# Altium schematic sheet Power.SchDoc
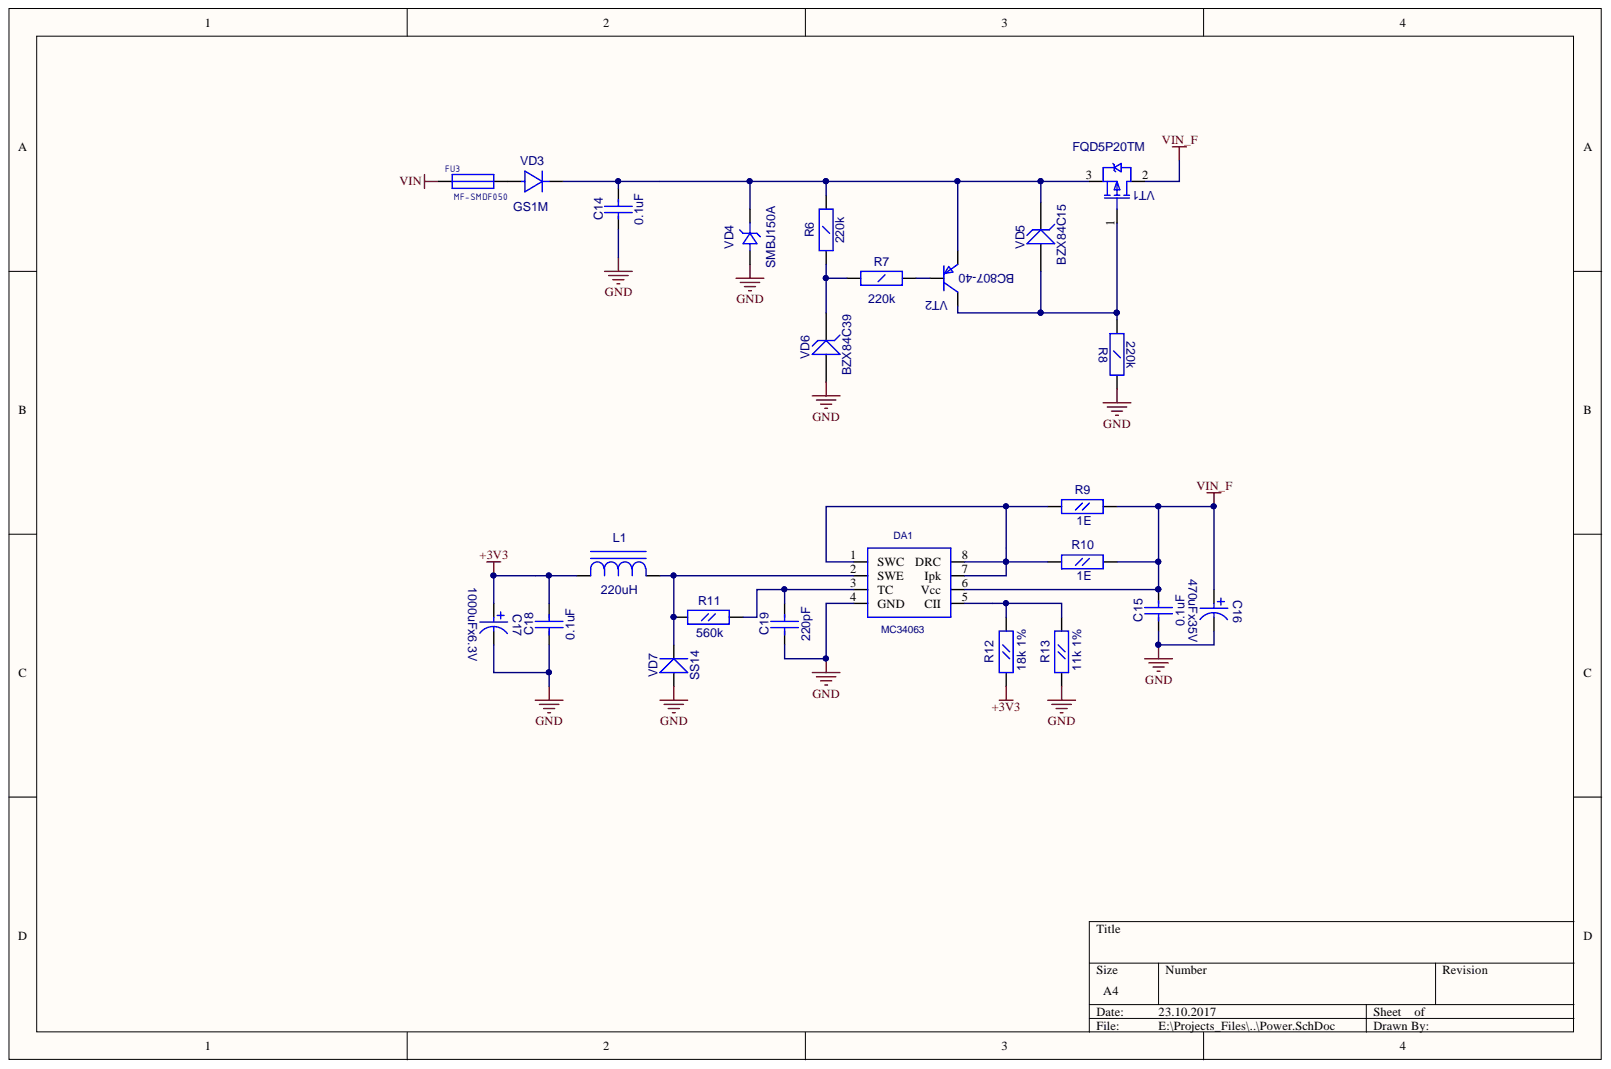
[diagram p0: Power.SchDoc]

## Parts
C14  0.1uF  [CC1206]
C15  0.1uF  [CC0603]
C16  470uFx35V  [CC16X10_CAP0.5(1)(Primary)]
C17  1000uFx6.3V  [CC8X3.5]
C18  0.1uF  [CC0603]
C19  220pF  [CC0603]
DA1  MC34063  [SO-8]
FU3  MF-SMDF050  [MF-SMDF]
L1  220uH  [SDR0805]
R6  220k  [RC1206]
R7  220k  [RC1206]
R8  220k  [RC1206]
R9  1E  [RC0805]
R10  1E  [RC0805]
R11  560k  [RC0603]
R12  18k 1%  [RC0603]
R13  11k 1%  [RC0603]
VD3  GS1M  [SMA]
VD4  SMBJ150A  [SMB]
VD5  BZX84C15  [SOT-23(123)]
VD6  BZX84C39  [SOT-23(123)]
VD7  SS14  [SMA]
VT1  FQD5P20TM  [D-PAK(TO-252AA)]
VT2  BC807-40  [SOT-23(123)]

## Nets
+3V3: C17.1 C18.2 L1.1 R12.1
GND: C14.1 C15.1 C16.1 C17.2 C18.1 C19.1 DA1.4(GND) R8.2 R13.1 VD4.1 VD6.1 VD7.1
VIN: FU3.1
VIN_F: C15.2 C16.2 DA1.6(Vcc) R9.2 R10.2 VT1.2
NetC14_2: C14.2 R6.2 VD3.2 VD4.2 VD5.3 VT1.3 VT2.2
NetC19_2: C19.2 DA1.3(TC) R11.2
NetDA1_1: DA1.1(SWC) DA1.7(Ipk) DA1.8(DRC) R9.1 R10.1
NetDA1_2: DA1.2(SWE) L1.2 R11.1 VD7.2
NetDA1_5: DA1.5(CII) R12.2 R13.2
NetFU3_2: FU3.2 VD3.1
NetR6_1: R6.1 R7.1 VD6.3
NetR7_2: R7.2 VT2.1
NetR8_1: R8.1 VD5.1 VT1.1 VT2.3

## Library data of the parts
C14 = CC1206: Component Kind: Standard; Description: CC1206; Value: 0.1uF; Library Name: gprs_modem_2.SchLib
C15 C18 C19 = CC0603: Component Kind: Standard; Description: CC0603; Library Name: gprs_modem_2.SchLib
  C15 C18: Value: 0.1uF
  C19: Value: 220pF
C16 = CAP0.5(1): Component Kind: Standard; Description: CAP0.5(1); Value: 470uFx35V; Library Name: gprs_modem_2.SchLib
C17 = CAP0.35: Component Kind: Standard; Description: CAP0.35; Value: 1000uFx6.3V; Library Name: gprs_modem_2.SchLib
FU3 = MF-SMDF: Component Kind: Standard; Description: MF-SMDF; Value: MF-SMDF050; Library Name: gprs_modem_2.SchLib
L1 = SDR0805: Component Kind: Standard; Description: SDR0805; Value: 220uH; Library Name: gprs_modem_2.SchLib
R6-R8 = RC1206: Component Kind: Standard; Description: RC1206; Value: 220k; Library Name: gprs_modem_2.SchLib
R9 R10 = RC0805: Component Kind: Standard; Description: RC0805; Value: 1E; Library Name: gprs_modem_2.SchLib
R11-R13 = RC0603: Component Kind: Standard; Description: RC0603; Library Name: gprs_modem_2.SchLib
  R11: Value: 560k
  R12: Value: 18k 1%
  R13: Value: 11k 1%
VD3 = GS1M: Value: {Value}; Component Kind: Standard; Description: GS1M; Library Name: gprs_modem.SchLib
VD4 = SMBJ: Component Kind: Standard; Description: SMBJ; Value: SMBJ150A; Library Name: gprs_modem_2.SchLib
VD5 VD6 = BZX84: Zener Voltage Regulator Diode; Value: {Value}; Component Kind: Standard; Library Name: gprs_modem_2.SchLib
VD7 = SS14: Schottky Rectifier; ComponentField6: Published 8-Jun-2000, Modified 10-July-2000: SIM Model Added.; OriginalName: 10BQ100; Component Kind: Standard; Simulation: 10BQ100; Value: SS14; Library Name: gprs_modem_2.SchLib
VT1 = IRFR9220: HEXFET Power MOSFET; ComponentField7: Published 8-Jun-2000; OriginalName: IRLML5103; Component Kind: Standard; Value: FQD5P20TM; Library Name: gprs_modem_2.SchLib
VT2 = BC857B: ComponentField7: Published 29-Sep-2000; OriginalName: BC846BLT1; Component Kind: Standard; Description: BC857B; Simulation: BC846BLT1; Value: BC807-40; Library Name: gprs_modem.SchLib
DA1 = MC34063: Component Kind: Standard; Description: MC34063; Library Name: Schetchik.SchLib
